# Altium schematic sheet [04] - POWER LOW STAGE.SchDoc("[04] - POWER LOW STAGE")
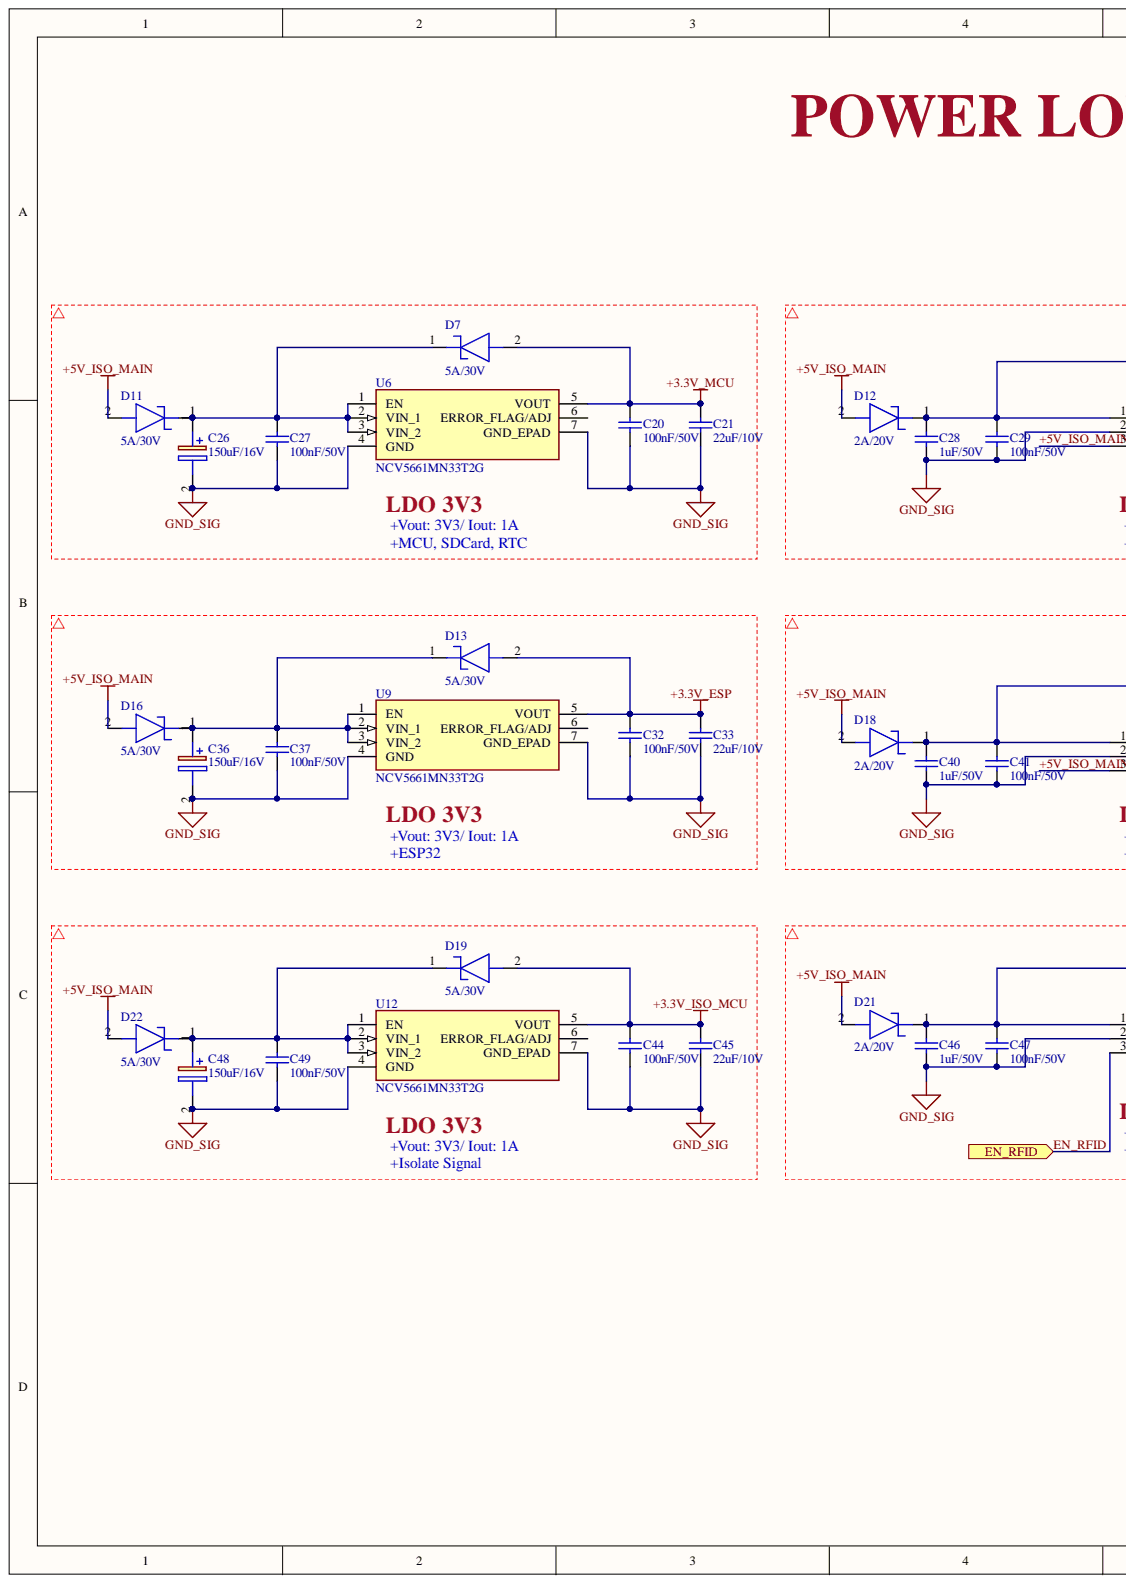
[diagram p0: [04] - POWER LOW STAGE.SchDoc, left]
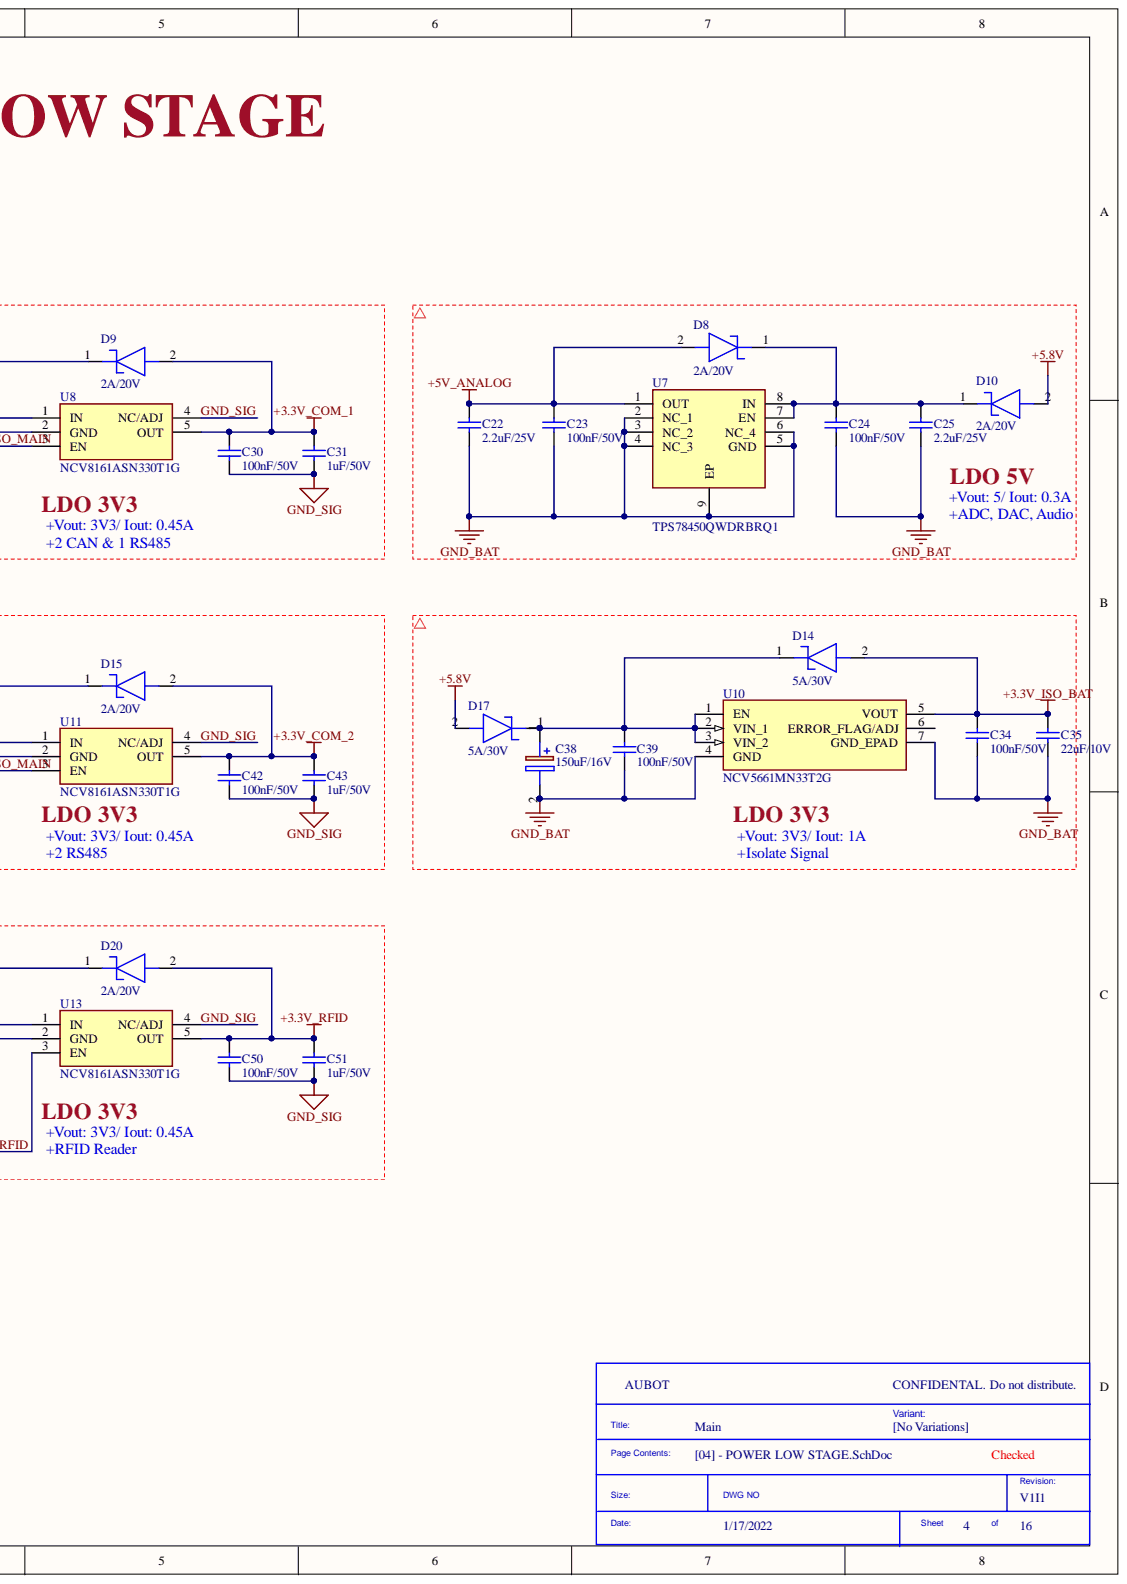
[diagram p1: [04] - POWER LOW STAGE.SchDoc, right]

## Parts
C20  100nF/50V  [CAP 0805]
C21  22uF/10V  [CAP 0805]
C22  2.2uF/25V  [CAP 0805]
C23  100nF/50V  [CAP 0805]
C24  100nF/50V  [CAP 0805]
C25  2.2uF/25V  [CAP 0805]
C26  150uF/16V  [TANTALUM 2917]
C27  100nF/50V  [CAP 0805]
C28  1uF/50V  [CAP 0805]
C29  100nF/50V  [CAP 0805]
C30  100nF/50V  [CAP 0805]
C31  1uF/50V  [CAP 0805]
C32  100nF/50V  [CAP 0805]
C33  22uF/10V  [CAP 0805]
C34  100nF/50V  [CAP 0805]
C35  22uF/10V  [CAP 0805]
C36  150uF/16V  [TANTALUM 2917]
C37  100nF/50V  [CAP 0805]
C38  150uF/16V  [TANTALUM 2917]
C39  100nF/50V  [CAP 0805]
C40  1uF/50V  [CAP 0805]
C41  100nF/50V  [CAP 0805]
C42  100nF/50V  [CAP 0805]
C43  1uF/50V  [CAP 0805]
C44  100nF/50V  [CAP 0805]
C45  22uF/10V  [CAP 0805]
C46  1uF/50V  [CAP 0805]
C47  100nF/50V  [CAP 0805]
C48  150uF/16V  [TANTALUM 2917]
C49  100nF/50V  [CAP 0805]
C50  100nF/50V  [CAP 0805]
C51  1uF/50V  [CAP 0805]
D7  5A/30V  [DIODE DO-221AC-2]
D8  2A/20V  [DIODE SOD-323-2]
D9  2A/20V  [DIODE SOD-323-2]
D10  2A/20V  [DIODE SOD-323-2]
D11  5A/30V  [DIODE DO-221AC-2]
D12  2A/20V  [DIODE SOD-323-2]
D13  5A/30V  [DIODE DO-221AC-2]
D14  5A/30V  [DIODE DO-221AC-2]
D15  2A/20V  [DIODE SOD-323-2]
D16  5A/30V  [DIODE DO-221AC-2]
D17  5A/30V  [DIODE DO-221AC-2]
D18  2A/20V  [DIODE SOD-323-2]
D19  5A/30V  [DIODE DO-221AC-2]
D20  2A/20V  [DIODE SOD-323-2]
D21  2A/20V  [DIODE SOD-323-2]
D22  5A/30V  [DIODE DO-221AC-2]
U6  NCV5661MN33T2G  [DFN-6_PAD]
U7  TPS78450QWDRBRQ1  [SON-8]
U8  NCV8161ASN330T1G  [TSOP-5]
U9  NCV5661MN33T2G  [DFN-6_PAD]
U10  NCV5661MN33T2G  [DFN-6_PAD]
U11  NCV8161ASN330T1G  [TSOP-5]
U12  NCV5661MN33T2G  [DFN-6_PAD]
U13  NCV8161ASN330T1G  [TSOP-5]

## Ports
EN_RFID

## Nets
+3.3V_COM_1: C30.2 C31.2 D9.2 U8.5(OUT)
+3.3V_COM_2: C42.2 C43.2 D15.2 U11.5(OUT)
+3.3V_ESP: C32.2 C33.2 D13.2 U9.5(VOUT)
+3.3V_ISO_BAT: C34.2 C35.2 D14.2 U10.5(VOUT)
+3.3V_ISO_MCU: C44.2 C45.2 D19.2 U12.5(VOUT)
+3.3V_MCU: C20.2 C21.2 D7.2 U6.5(VOUT)
+3.3V_RFID: C50.2 C51.2 D20.2 U13.5(OUT)
+5.8V: D10.2 D17.2
+5V_ANALOG: C22.1 C23.2 D8.2 U7.1(OUT)
GND_BAT: C22.2 C23.1 C24.2 C25.2 C34.1 C35.1 C38.2 C39.1 U7.2(NC_1) U7.3(NC_2) U7.4(NC_3) U7.5(GND) U7.6(NC_4) U7.9(EP) U10.4(GND) U10.7(GND_EPAD)
+5V_ISO_MAIN: D11.2 D12.2 D16.2 D18.2 D21.2 D22.2 U8.3(EN) U11.3(EN)
EN_RFID: U13.3(EN) ; port EN_RFID
GND_SIG: C20.1 C21.1 C26.2 C27.1 C28.1 C29.1 C30.1 C31.1 C32.1 C33.1 C36.2 C37.1 C40.1 C41.1 C42.1 C43.1 C44.1 C45.1 C46.1 C47.1 C48.2 C49.1 C50.1 C51.1 U6.4(GND) U6.7(GND_EPAD) U8.2(GND) U8.4(NC/ADJ) U9.4(GND) U9.7(GND_EPAD) U11.2(GND) U11.4(NC/ADJ) U12.4(GND) U12.7(GND_EPAD) U13.2(GND) U13.4(NC/ADJ)
NetC24_1: C24.1 C25.1 D8.1 D10.1 U7.7(EN) U7.8(IN)
NetC26_1: C26.1 C27.2 D7.1 D11.1 U6.1(EN) U6.2(VIN_1) U6.3(VIN_2)
NetC28_2: C28.2 C29.2 D9.1 D12.1 U8.1(IN)
NetC36_1: C36.1 C37.2 D13.1 D16.1 U9.1(EN) U9.2(VIN_1) U9.3(VIN_2)
NetC38_1: C38.1 C39.2 D14.1 D17.1 U10.1(EN) U10.2(VIN_1) U10.3(VIN_2)
NetC40_2: C40.2 C41.2 D15.1 D18.1 U11.1(IN)
NetC46_2: C46.2 C47.2 D20.1 D21.1 U13.1(IN)
NetC48_1: C48.1 C49.2 D19.1 D22.1 U12.1(EN) U12.2(VIN_1) U12.3(VIN_2)

Not connected: U6 6(ERROR_FLAG/ADJ); U9 6(ERROR_FLAG/ADJ); U10 6(ERROR_FLAG/ADJ); U12 6(ERROR_FLAG/ADJ)

## Library data of the parts
C20 C23 C24 C27 C29 C30 C32 C34 C37 C39 C41 C42 C44 C47 C49 C50 = Cap 0805 100nF/50V: Ceramic capacitor SMD-0805 100nF /50V X7R# K +-10%, Samsung, RoHS; Manufacturer Part Number: CL21B104KBCNNNC; Voltage Rating (DC): 50V; Tolerance: 10%; Dielectric: X7R; Width: 0.049inch; Voltage Rating: 50V; Voltage: 50V; Thickness: 0.037inch; Supplier Part Number 1: C1711; Supplier 1: LCSC; Stock 1: 447650; Series: CL; RoHS Compliant: Yes; RoHS: Yes; Radiation Hardening: No; Pricing 1: 50 = 0.04090, 500 = 0.03130, 1500 = 0.02890, 5000 = 0.02580, 25000 = 0.02430, 50000 = 0.02410, 50 = 0.03950, 500 = 0.03020, 1500 = 0.02790, 5000 = 0.02490, 25000 = 0.02350, 50000 = 0.02330, 50 = 0.20680, 500 = 0.15820, 1500 = 0.14600, 5000 = 0.13020, 25000 = 0.12290, 50000 = 0.12170, 50 = 0.19640, 500 = 0.15020, 1500 = 0.13860, 5000 = 0.12360, 25000 = 0.11670, 50000 = 0.11550, 50 = 0.02640, 500 = 0.02020, 1500 = 0.01860, 5000 = 0.01660, 25000 = 0.01570, 50000 = 0.01550, 50 = 0.02300, 500 = 0.01760, 1500 = 0.01620, 5000 = 0.01440, 25000 = 0.01360, 50000 = 0.01350, 50 = 0.24740, 500 = 0.18920, 1500 = 0.17470, 5000 = 0.15570, 25000 = 0.14700, 50000 = 0.14560, 50 = 3.45220, 500 = 2.64000, 1500 = 2.43700, 5000 = 2.17300, 25000 = 2.05110, 50000 = 2.03080, 50 = 0.13070, 500 = 0.09990, 1500 = 0.09220, 5000 = 0.08230, 25000 = 0.07760, 50000 = 0.07690, 50 = 2.39050, 500 = 1.82810, 1500 = 1.68750, 5000 = 1.50470, 25000 = 1.42030, 50000 = 1.40620, 50 = 0.26740, 500 = 0.20450, 1500 = 0.18870, 5000 = 0.16830, 25000 = 0.15890, 50000 = 0.15730, 50 = 0.04230, 500 = 0.03230, 1500 = 0.02990, 5000 = 0.02660, 25000 = 0.02510, 50000 = 0.02490, 50 = 0.03188, 500 = 0.02438, 1500 = 0.02251, 5000 = 0.02007, 25000 = 0.01894, 50000 = 0.01875 (AUD); Packaging: Tape and Reel; Mount: Surface Mount; Min Operating Temperature: -55°C; Max Operating Temperature: 125°C; Material: Ceramic; Manufacturer: Samsung; Length: 2mm; Lead Free: Lead Free; Height: 0.85mm; Depth: 1.25mm; Contact Plating: Tin; Construction: Flat; ComponentLink2URL: https://datasheet.ciiva.com/29199/454152-da-01-en-vs-kondensator-0805-29199814.pdf?src-supplier=Conrad; ComponentLink2Description: https://datasheet.ciiva.com/29199/454152-da-01-en-vs-kondensator-0805-29199814.pdf?src-supplier=Conrad; ComponentLink1URL: https://datasheet.ciiva.com/25710/cl21b104kbcnnnc-25710182.pdf?src-supplier=Digi-Key; ComponentLink1Description: https://datasheet.ciiva.com/25710/cl21b104kbcnnnc-25710182.pdf?src-supplier=Digi-Key; Component Kind: Standard; Case Code (Metric): 2012; Case Code (Imperial): 0805; Case/Package: 0805; Capacitance: 100nF; Library Name: Hieu_Lib.IntLib
C21 C33 C35 C45 = Cap 0805 22uF/10V: 0805 22 uF 10 V ±20 % Tolerance X5R SMT Multilayer Ceramic Capacitor; Manufacturer Part Number: CC0805MKX5R6BB226; Manufacturer: Yageo; Voltage Rating (DC): 10V; Tolerance: 20%; Capacitance: 22uF; Width: 1.25mm; Weight: 0.000194oz; Voltage Rating: 10V; Thickness: 0.057inch; Termination: SMD/SMT; Supplier Part Number 1: 311-1900-1-ND; Supplier 1: Digi-Key; Stock 1: 24; RoHS: Yes; Resistor Type: Ceramic; Radiation Hardening: No; Pricing 1: 1 = 0.41000, 10 = 0.28600, 50 = 0.20000, 100 = 0.17140, 500 = 0.12854, 1000 = 0.10855 (USD); Packaging: Tape and Reel; Mount: Surface Mount; Min Operating Temperature: -55°C; Max Operating Temperature: 85°C; Length: 2mm; Lead Free: Lead Free; Halogen Free: Halogen Free; Dielectric: X5R; Depth: 1.25mm; ComponentLink1URL: https://datasheet.ciiva.com/26863/getdatasheetpartid-573628-26863004.pdf?src-supplier=Verical; ComponentLink1Description: https://datasheet.ciiva.com/26863/getdatasheetpartid-573628-26863004.pdf?src-supplier=Verical; Component Kind: Standard; Case Code (Metric): 2012; Case Code (Imperial): 0805; Case/Package: 0805; Library Name: Hieu_Lib.IntLib
C22 C25 = Cap 0805 2.2uF/25V: YAGEO - CC0805KKX7R8BB225 - SMD Multilayer Ceramic Capacitor, 2.2 µF, 25 V, 0805 [2012 Metric], ± 10%, X7R, CC Series; Voltage Rating (DC): 25V; Capacitance: 2.2uF; Width: 1.25mm; Voltage Rating: 25V; Tolerance: 10%; Thickness: 0.057inch; Termination: SMD/SMT; Supplier Part Number 1: 603-CC805KKX7R8BB225; Supplier 1: Mouser; Stock 1: 57440; Series: CC; RoHS Compliant: Yes; RoHS: Yes; Resistor Type: Ceramic; REACH SVHC: Unknown; Radiation Hardening: No; Pricing 1: 1 = 0.38000, 10 = 0.20600, 50 = 0.20600, 100 = 0.15000, 1000 = 0.09500, 10000 = 0.08900 (USD); Packaging: Tape and Reel; Number of Pins: 2; Mount: Surface Mount; Min Operating Temperature: -55°C; Max Operating Temperature: 125°C; Manufacturer Part Number: CC0805KKX7R8BB225; Manufacturer: Yageo; Length: 2mm; Lead Free: Lead Free; Height: 0.3mm; Halogen Free: Halogen Free; Dielectric: X7R; Depth: 1.25mm; ComponentLink1URL: https://datasheet.ciiva.com/7009/775840-7009706.pdf?src-supplier=Newark; ComponentLink1Description: https://datasheet.ciiva.com/7009/775840-7009706.pdf?src-supplier=Newark; Component Kind: Standard; Case Code (Metric): 2012; Case Code (Imperial): 0805; Case/Package: 0805; Library Name: Hieu_Lib.SchLib
C26 C36 C38 C48 = Cap Tant 2917 150uF/16V: Cap Tant Polymer 150uF 16VDC Y CASE 20%( 7.3 X 4.3 X 2mm) SMD 7343-20 0.05 Ohm 85C T/R; Manufacturer Part Number: TCJY157M016R0050; Manufacturer: Kyocera AVX; Voltage Rating: 16V; Life (Hours): 2000Hour; ESR (Equivalent Series Resistance): 50mR; Width: 0.169inch; Tolerance: 20%; Supplier Part Number 1: 478-9587-1-ND; Supplier 1: Digi-Key; Stock 1: 0; RoHS Compliant: Yes; RoHS: Yes; Packaging: Tape and Reel; Mount: Surface Mount; Min Operating Temperature: -55°C; Max Operating Temperature: 85°C; Length: 0.287inch; Height - Seated (Max): 0.079inch; Features: General Purpose; ComponentLink2URL: http://catalogs.avx.com/TantalumNiobium.pdf?src-supplier=Digi-Key; ComponentLink2Description: http://catalogs.avx.com/TantalumNiobium.pdf?src-supplier=Digi-Key; ComponentLink1URL: http://datasheets.avx.com/TCJ.pdf?src-supplier=Digi-Key; ComponentLink1Description: http://datasheets.avx.com/TCJ.pdf?src-supplier=Digi-Key; Component Kind: Standard; Case Code (Metric): 7343; Case Code (Imperial): 2917; Case/Package: 2917; Capacitance: 150uF; Library Name: Hieu_Lib.SchLib
C28 C31 C40 C43 C46 C51 = Cap 0805 1uF/50V: 0805 1 uF 50 V ±10 % Tolerance X7R SMT Multilayer Ceramic Capacitor; Manufacturer Part Number: CC0805KKX7R9BB105; Manufacturer: Yageo; Voltage Rating (DC): 50V; Tolerance: 10%; Capacitance: 1uF; Width: 0.049inch; Weight: 0.000194oz; Voltage Rating: 50V; Thickness: 0.057inch; Termination: SMD/SMT; Supplier Part Number 1: 311-1886-1-ND; Supplier 1: Digi-Key; Stock 1: 174238; Series: CC; RoHS Compliant: Yes; RoHS: Yes; Resistor Type: Ceramic; Radiation Hardening: No; Pricing 1: 1 = 0.26000, 10 = 0.17900, 50 = 0.11920, 100 = 0.10130, 500 = 0.07152, 1000 = 0.06257 (USD); Packaging: Tape and Reel; Mount: Surface Mount; Min Operating Temperature: -55°C; Max Operating Temperature: 125°C; Length: 2mm; Lead Free: Lead Free; Height: 1.25mm; Halogen Free: Halogen Free; Dielectric: X7R; Depth: 1.25mm; Construction: Flat; ComponentLink1URL: https://datasheet.ciiva.com/13209/upy-gphc-x7r-6-3v-to-50v-8-12304-13209375.pdf?src-supplier=Mouser; ComponentLink1Description: https://datasheet.ciiva.com/13209/upy-gphc-x7r-6-3v-to-50v-8-12304-13209375.pdf?src-supplier=Mouser; Component Kind: Standard; Case Code (Metric): 2012; Case Code (Imperial): 0805; Case/Package: 0805; Library Name: Hieu_Lib.IntLib
D7 D11 D13 D14 D16 D17 D19 D22 = Rectifier Diode Schottky 30V 5A: Rectifier Diode Schottky 30V 5A 2-Pin SMA-FL T/R; Manufacturer Part Number: SK53AFL-TP; Manufacturer: MCC; Supplier Part Number 1: SK53AFL-TPMSCT-ND; Supplier 1: Digi-Key; Stock 1: 14531; RoHS Compliant: Yes; RoHS: Yes; Pricing 1: 1 = 0.39000, 10 = 0.31200, 100 = 0.21250, 500 = 0.15938, 1000 = 0.11953, 2000 = 0.10957, 5000 = 0.10293 (USD); Packaging: Tape and Reel; Mount: Surface Mount; Max Reverse Voltage (DC): 30V; ComponentLink1URL: http://www.mccsemi.com/up_pdf/SK52AFL-SK5200AFL(DO-221AC).pdf?src-supplier=Digi-Key; ComponentLink1Description: http://www.mccsemi.com/up_pdf/SK52AFL-SK5200AFL(DO-221AC).pdf?src-supplier=Digi-Key; Component Kind: Standard; Average Rectified Current: 5A; Library Name: Hieu_Lib.IntLib
D8-D10 D12 D15 D18 D20 D21 = Diode Schottky 2A: SDM2U20SD3 Series 20 V 2 A Surface Mount Schottky Barrier Rectifier - SOD-323; Manufacturer Part Number: SDM2U20SD3-7; Manufacturer: Diodes; Max Reverse Voltage (DC): 20V; Average Rectified Current: 2A; Supplier Part Number 1: 621-SDM2U20SD3-7; Supplier 1: Mouser; Stock 1: 485; RoHS Compliant: Yes; RoHS: Yes; Pricing 1: 1 = 0.46000, 10 = 0.35200, 50 = 0.35200, 100 = 0.20000, 1000 = 0.10200, 10000 = 0.07000 (USD); Packaging: Cut Tape; Mount: Surface Mount; ComponentLink3URL: http://diodes.com/datasheets/SDM2U20SD3.pdf?src-supplier=Avnet; ComponentLink3Description: http://diodes.com/datasheets/SDM2U20SD3.pdf?src-supplier=Avnet; ComponentLink2URL: https://www.diodes.com/assets/Datasheets/SDM2U20SD3.pdf?src-supplier=Digi-Key; ComponentLink2Description: https://www.diodes.com/assets/Datasheets/SDM2U20SD3.pdf?src-supplier=Digi-Key; ComponentLink1URL: http://www.mouser.com/ds/2/115/SDM2U20SD3-537776.pdf?src-supplier=Mouser; ComponentLink1Description: http://www.mouser.com/ds/2/115/SDM2U20SD3-537776.pdf?src-supplier=Mouser; Component Kind: Standard; Library Name: Hieu_Lib.IntLib
U6 U9 U10 U12 = NCV5661MN33T2G: Linear Voltage Regulator, LDO, Fast Response, 1.0 A, with Enable, V out : 3.3 V; Manufacturer: ON Semiconductor; Reference Voltage: 900mV; Quiescent Current: 3mA; Polarity: Positive; Output Voltage Accuracy: 1%; Output Voltage: 3.3V; Output Type: Fixed; Output Current: 1A; Number of Regulators: 1; Min Input Voltage: 2V; Max Input Voltage: 9V; Dropout Voltage: 1V; Width: 3mm; Supplier Part Number 1: 863-NCV5661MN33T2G; Supplier 1: Mouser; Stock 1: 3815; Series: Automotive, AEC-Q100; RoHS Compliant: Yes; RoHS: Yes; Radiation Hardening: No; Pricing 1: 1 = 1.16000, 10 = 1.05000, 50 = 0.99300, 100 = 0.81600, 1000 = 0.53200, 10000 = 0.51900 (USD); Packaging: Tape and Reel; Package Quantity: 3000; Number of Pins: 6; Number of Outputs: 1; Mount: Surface Mount; Min Operating Temperature: -40°C; Max Output Current: 1A; Max Operating Temperature: 150°C; Manufacturer Part Number: NCV5661MN33T2G; Length: 3.3mm; Lead Free: Lead Free; Height: 0.85mm; Halogen Free: Halogen Free; ComponentLink1URL: https://datasheet.ciiva.com/2062/ncp5661-d-2062579.pdf?src-supplier=Digi-Key; ComponentLink1Description: https://datasheet.ciiva.com/2062/ncp5661-d-2062579.pdf?src-supplier=Digi-Key; Component Kind: Standard; Case/Package: DFN; Accuracy: 1%; Library Name: Hieu_Lib.SchLib
U7 = TPS78450QWDRBRQ1: Automotive, 300-mA, low-IQ, high-PSRR, LDO voltage regulator with high accuracy and enable 8-SON -40 to 150; Manufacturer: Texas Instruments; Supplier Part Number 1: 595-TPS78450QWDRBRQ1; Supplier 1: Mouser; Stock 1: 2807; RoHS: Yes; Pricing 1: 1 = 0.99000, 10 = 0.86800, 50 = 0.86800, 100 = 0.66600, 250 = 0.61800, 500 = 0.52600, 1000 = 0.42100, 3000 = 0.38100, 10000 = 0.38100 (USD); Manufacturer Part Number: TPS78450QWDRBRQ1; Component Kind: Standard; Library Name: Hieu_Lib.IntLib
U8 U11 U13 = NCV8161ASN330T1G: LDO Regulator, 450 mA, Low Dropout, Low Iq, Ultra-High PSRR, Ultra-Low Noise 3.3V, Active Discharge, TSOP-5; Manufacturer: ON Semiconductor; Quiescent Current: 23uA; Power Supply Rejection Ratio (PSRR): 48dB; Output Type: Fixed; Output Current: 450mA; Output Configuration: Positive; Number of Regulators: 1; Max Input Voltage: 5.5V; Dropout Voltage: 305mV; Supplier Part Number 1: 863-NCV8161ASN330T1G; Supplier 1: Mouser; Stock 1: 527; RoHS Compliant: Yes; RoHS: Yes; Pricing 1: 1 = 0.76000, 10 = 0.66500, 50 = 0.66500, 100 = 0.52000, 1000 = 0.35000, 10000 = 0.33800 (USD); Packaging: Tape and Reel; Mount: Surface Mount; Min Output Voltage: 3.3V; Min Operating Temperature: -40°C; Max Operating Temperature: 125°C; Manufacturer Part Number: NCV8161ASN330T1G; ComponentLink3URL: http://www.onsemi.com/pub/Collateral/NCV8161-D.PDF?src-supplier=Digi-Key; ComponentLink3Description: http://www.onsemi.com/pub/Collateral/NCV8161-D.PDF?src-supplier=Digi-Key; ComponentLink2URL: http://www.farnell.com/datasheets/2290244.pdf?src-supplier=Newark; ComponentLink2Description: http://www.farnell.com/datasheets/2290244.pdf?src-supplier=Newark; ComponentLink1URL: http://www.farnell.com/datasheets/2290244.pdf?src-supplier=Farnell; ComponentLink1Description: http://www.farnell.com/datasheets/2290244.pdf?src-supplier=Farnell; Component Kind: Standard; Case/Package: SOT-23-5; Library Name: Hieu_Lib.IntLib
